# Altium schematic sheet IGBT_half_bridge.SchDoc(IGBT_half_bridge)
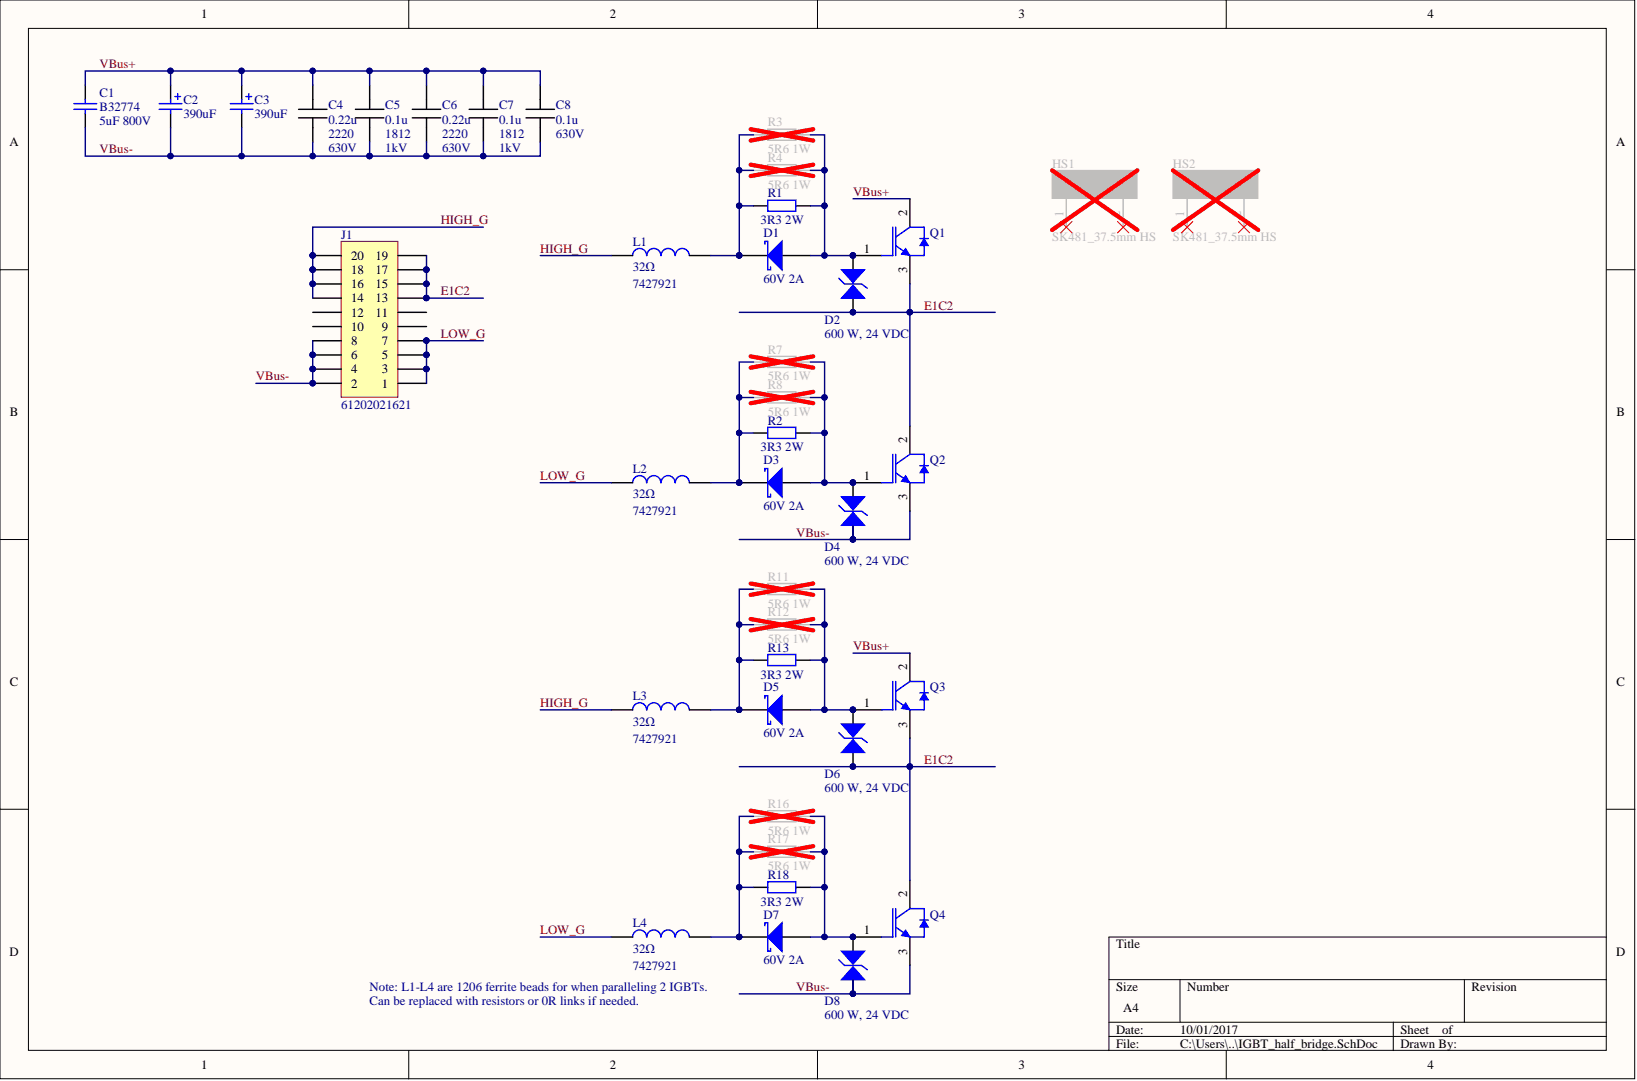
[diagram p0: IGBT_half_bridge.SchDoc]

## Parts
C1  B32774  value 5uF 800V  [B32774 5uF 800V]
C2  390uF  [WCAP-AIG8_30x46]
C3  390uF  [WCAP-AIG8_30x46]
C4  voltage: 630V  package: 2220  Capacitance: 0.22u  [2220C]  (hidden comment: Capacitor)
C5  voltage: 1kV  package: 1812  Capacitance: 0.1u  [1812C]  (hidden comment: Capacitor)
C6  voltage: 630V  package: 2220  Capacitance: 0.22u  [2220C]  (hidden comment: Capacitor)
C7  voltage: 1kV  package: 1812  Capacitance: 0.1u  [1812C]  (hidden comment: Capacitor)
C8  voltage: 630V  Capacitance: 0.1u  [Cap_multi_footprint]  (hidden comment: Capacitor)
D1  60V 2A  [DO-214AA_(SMB-J-BEND)_UNI]
D2  600 W, 24 VDC  [DO-214AA_Bidirectional]
D3  60V 2A  [DO-214AA_(SMB-J-BEND)_UNI]
D4  600 W, 24 VDC  [DO-214AA_Bidirectional]
D5  60V 2A  [DO-214AA_(SMB-J-BEND)_UNI]
D6  600 W, 24 VDC  [DO-214AA_Bidirectional]
D7  60V 2A  [DO-214AA_(SMB-J-BEND)_UNI]
D8  600 W, 24 VDC  [DO-214AA_Bidirectional]
HS1  SK481_37.5mm HS  [SK 481 37.5]
HS2  SK481_37.5mm HS  [SK 481 37.5_FLIP]
J1  61202021621  [61202021621]
L1  32Ω  PartNumber: 7427921  [WE-CBF_1206_High Speed]
L2  32Ω  PartNumber: 7427921  [WE-CBF_1206_High Speed]
L3  32Ω  PartNumber: 7427921  [WE-CBF_1206_High Speed]
L4  32Ω  PartNumber: 7427921  [WE-CBF_1206_High Speed]
Q1  (no value)  [TO-247_Horizontal]
Q1  (no value)  [TO-247_Horizontal]
Q2  (no value)  [TO-247_Horizontal]
Q2  (no value)  [TO-247_Horizontal]
Q3  (no value)  [TO-247_Horizontal]
Q3  (no value)  [TO-247_Horizontal]
Q4  (no value)  [TO-247_Horizontal]
Q4  (no value)  [TO-247_Horizontal]
R1  value 3R3 2W  [Res_Radial_2W]
R2  value 3R3 2W  [Res_Radial_2W]
R3  value 5R6 1W  [RES_2512]  (hidden comment: Res2)
R4  value 5R6 1W  [RES_2512]  (hidden comment: Res2)
R7  value 5R6 1W  [RES_2512]  (hidden comment: Res2)
R8  value 5R6 1W  [RES_2512]  (hidden comment: Res2)
R11  value 5R6 1W  [RES_2512]  (hidden comment: Res2)
R12  value 5R6 1W  [RES_2512]  (hidden comment: Res2)
R13  value 3R3 2W  [Res_Radial_2W]
R16  value 5R6 1W  [RES_2512]  (hidden comment: Res2)
R17  value 5R6 1W  [RES_2512]  (hidden comment: Res2)
R18  value 3R3 2W  [Res_Radial_2W]

## Nets
E1C2: D2.2 D6.2 J1.13 J1.15 J1.17 J1.19 Q1.3 Q2.2 Q3.3 Q4.2
HIGH_G: J1.14 J1.16 J1.18 J1.20 L1.1 L3.1
LOW_G: J1.1 J1.3 J1.5 J1.7 L2.1 L4.1
VBus+: C1.1 C2.1 C3.1 C4.1 C5.1 C6.1 C7.1 C8.2 Q1.2 Q3.2
VBus-: C1.2 C2.2 C3.2 C4.2 C5.2 C6.2 C7.2 C8.1 D4.2 D8.2 J1.2 J1.4 J1.6 J1.8 Q2.3 Q4.3
NetD1_A: D1.A D2.1 Q1.1 R1.2 R3.2 R4.2
NetD1_K: D1.K L1.2 R1.1 R3.1 R4.1
NetD3_A: D3.A D4.1 Q2.1 R2.2 R7.2 R8.2
NetD3_K: D3.K L2.2 R2.1 R7.1 R8.1
NetD5_A: D5.A D6.1 Q3.1 R11.2 R12.2 R13.2
NetD5_K: D5.K L3.2 R11.1 R12.1 R13.1
NetD7_A: D7.A D8.1 Q4.1 R16.2 R17.2 R18.2
NetD7_K: D7.K L4.2 R16.1 R17.1 R18.1

Not connected: HS1 1 2; HS2 1 2; J1 9 10 11 12

## Library data of the parts
C1 = Cap: Capacitor; Value: 5uF 800V; Simulation: CAP; Signal Integrity: Cap; Published: 8-Jun-2000; PackageReference: RAD-0.3; PackageDescription: Radial Cap, Thru-Hole; 2 Leads; 0.3 in Pin Spacing; Library Name: Miscellaneous Devices.IntLib; LatestRevisionNote: Re-released for DXP Platform.; LatestRevisionDate: 17-Jul-2002; Component Kind: Standard; Publisher: Altium Limited
C2 C3 = WCAP-AIG8, 861011485019: WCAP-AIG8 Aluminum Electrolytic Capacitors, 390uF; RatedVoltage: 450V; Value: 390uF; Size: 30mmx46mm; PublishedDate: July 2016; PartNumber: 861011485019; ComponentLink2URL: http://katalog.we-online.de/pbs/datasheet/861011485019.pdf; ComponentLink2Description: Datasheet; ComponentLink1URL: http://www.we-online.de; ComponentLink1Description: Manufacturer; Component Kind: Standard; Publisher: Wurth Elektronik; Library Name: TV_Altium_library.SchLib
D1 D3 D5 D7 = SS26: SS26 Schottky rectifier; Component Kind: Standard; Library Name: QCW Bridge PCBA.SchLib
D2 D4 D6 D8 = WE-TVSP DO-214AA, 824521241: WE-TVSP Power TVS Diode, bidirectional, 600 W, 24 VDC; Manufacturer: Wurth Elektronik; partNo: 824521241; Created Date: Nov.2015; ComponentLink2URL: http://katalog.we-online.de/pbs/datasheet/824521241.pdf; ComponentLink2Description: Datasheet; ComponentLink1URL: http://www.we-online.de; ComponentLink1Description: Manufacturer; Component Kind: Standard; Library Name: QCW Bridge PCBA.SchLib; Created by: Baojun Chen
HS1 HS2 = SK_481_37.5: Component Kind: Standard; Library Name: QCW Bridge PCBA.SchLib
J1 = 61202021621: WR-BHD 2.54mm Male Box Header; Manufacturer: Wurth Elektronik; NoPins: 20; ComponentLink2 Description: Datasheet; ComponentLink1 Description: Manufacturer; partNo: 61202021621; Created Date: March 2016; ComponentLink2URL: http://katalog.we-online.de/em/datasheet/6120xx21621.pdf; ComponentLink1URL: http://www.we-online.com; Component Kind: Standard; Library Name: QCW Bridge PCBA.SchLib; Created by: Baojun Chen
L1-L4 = WE-CBF, 7427921: WE-CBF SMD EMI Suppression Ferrite Bead, 1206, 32Ω; Type: High Speed; Value: 32Ω; Size: 1206; PublishedDate: Aug 2016; ComponentLink2URL: http://katalog.we-online.de/pbs/datasheet/7427921.pdf; ComponentLink2Description: Datasheet; ComponentLink1URL: http://www.we-online.de; ComponentLink1Description: Manufacturer; Component Kind: Standard; Publisher: Wurth Elektronik; Library Name: QCW Bridge PCBA.SchLib
Q1 Q1 Q2 Q2 Q3 Q3 Q4 Q4 = TO-247_IGBT_H: IGBT, TO-247, Horizontal; Component Kind: Standard
  Q1-Q4: Library Name: QCW Bridge PCBA.SchLib
R1 R2 = Res2: Resistor; Value: 3R3 2W; Simulation: RESISTOR; Signal Integrity: Res; Published: 8-Jun-2000; PackageReference: AXIAL-0.4; PackageDescription: Axial Device, Thru-Hole; 2 Leads; 0.4 in Pin Spacing; Library Name: Miscellaneous Devices.IntLib; LatestRevisionNote: Re-released for DXP Platform.; LatestRevisionDate: 17-Jul-2002; Component Kind: Standard; Publisher: Altium Limited
R3 R7 R11 R16 = Res2: Resistor; Value: 5R6 1W; Simulation: RESISTOR; Signal Integrity: Res; Published: 8-Jun-2000; PackageReference: AXIAL-0.4; PackageDescription: Axial Device, Thru-Hole; 2 Leads; 0.4 in Pin Spacing; Library Name: Miscellaneous Devices.IntLib; LatestRevisionNote: Re-released for DXP Platform.; LatestRevisionDate: 17-Jul-2002; Component Kind: Standard; Publisher: Altium Limited
R4 R8 R12 R13 R17 R18 = Res2: Resistor; Simulation: RESISTOR; Signal Integrity: Res; Published: 8-Jun-2000; PackageReference: AXIAL-0.4; PackageDescription: Axial Device, Thru-Hole; 2 Leads; 0.4 in Pin Spacing; Library Name: Miscellaneous Devices.IntLib; LatestRevisionNote: Re-released for DXP Platform.; LatestRevisionDate: 17-Jul-2002; Component Kind: Standard; Publisher: Altium Limited
C4-C8 = Capacitor: Component Kind: Standard; Library Name: TV_Altium_library.SchLib
